# Altium schematic sheet СФ МЭИ ЛБ ПЭ2-18 11.03.04 03 Э3.SchDoc
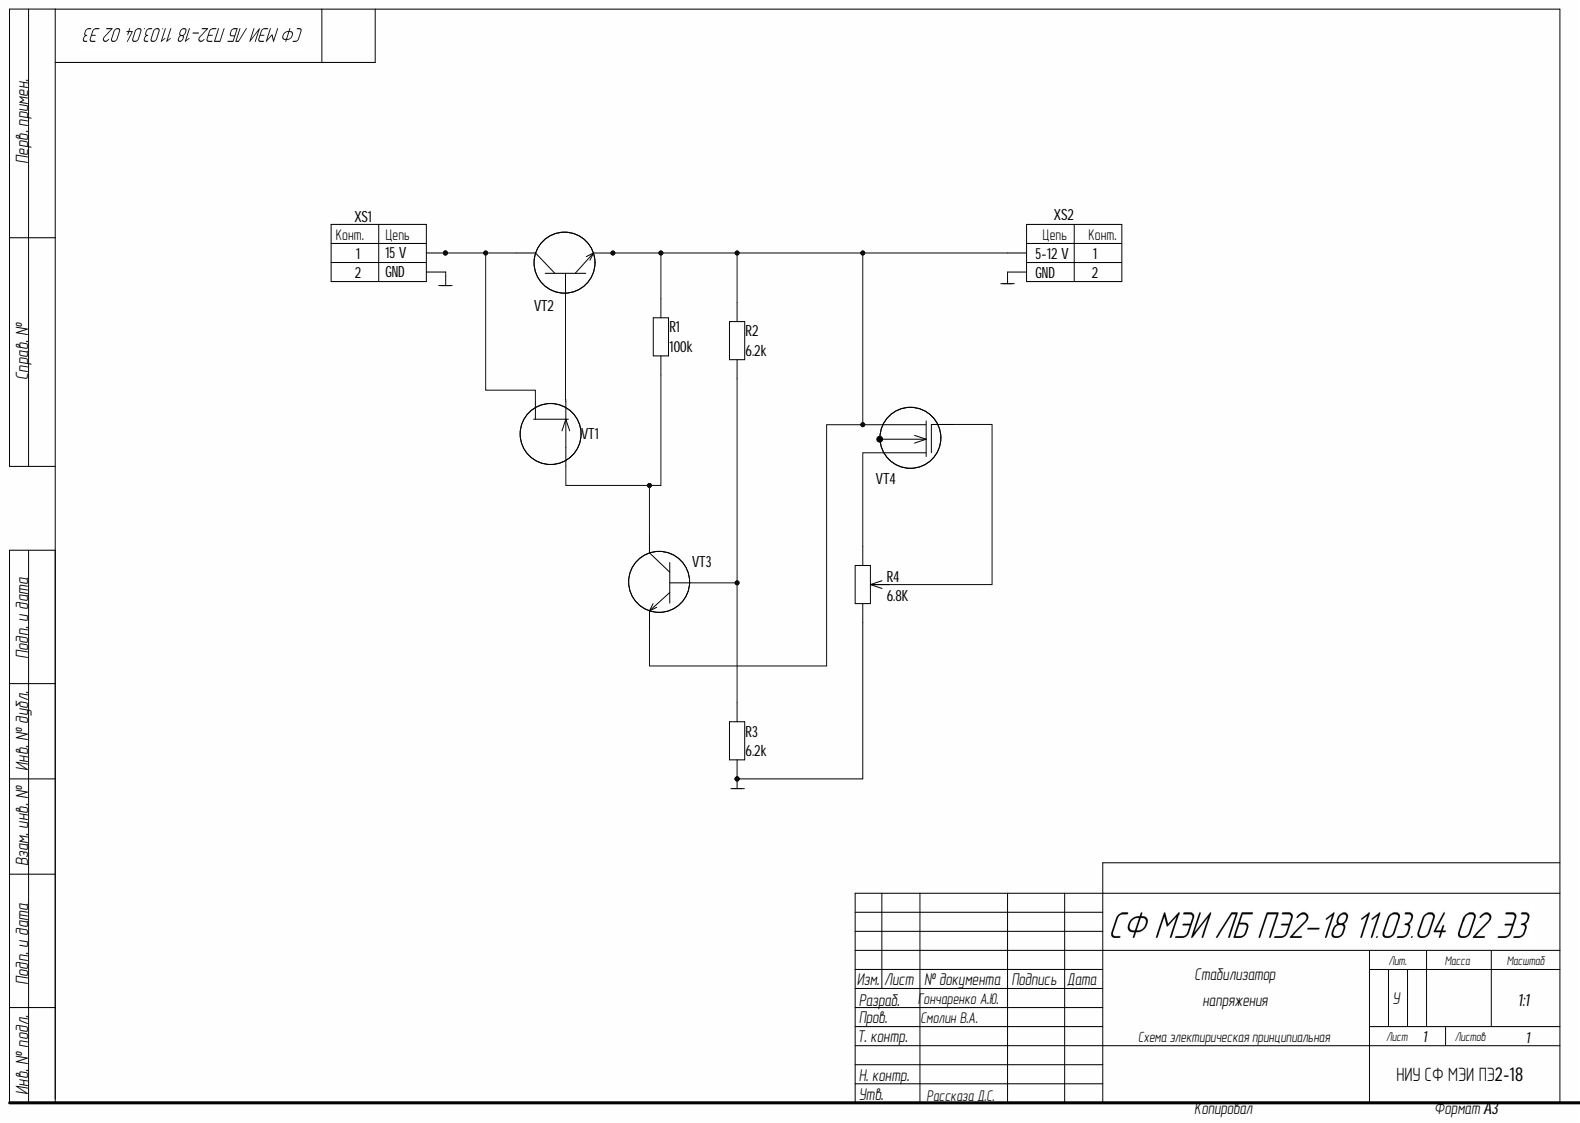
[diagram p0: СФ МЭИ ЛБ ПЭ2-18 11.03.04 03 Э3.SchDoc]

## Parts
R1  100k  [0805]
R2  6.2k  [0805]
R3  6.2k  [0805]
R4  6.8K  [ST32]
VT1  Полевойтранзистор с изолированым затвором  [SOT23]  (value not drawn)
VT2  Биполярный транзистор n-p-n  [SOT23]  (value not drawn)
VT3  Биполярный транзистор n-p-n  [SOT23]  (value not drawn)
VT4  Полевой транзистор с изолированым затвором n-типа  [SOT23]  (value not drawn)
XS1  SM02B  [CI1102M1H  (F)]  (value not drawn)
XS2  SM02B  [CI1102M1H  (F)]  (value not drawn)

## Nets
NetR1_1: R1.1 VT1.3 VT3.2
NetR1_2: R1.2 R2.2 VT2.3 VT3.3 VT4.2 XS2.1
NetR2_1: R2.1 R3.2 VT3.1
NetR3_1: R3.1 R4.2 XS1.2 XS2.2
NetR4_1: R4.1 VT4.3
NetR4_3: R4.3 VT4.1
NetVT1_1: VT1.1 VT2.1
NetVT1_2: VT1.2 VT2.2 XS1.1

## Library data of the parts
R1 = 0.125Вт 0805 100 кОм, 5%, Чип резистор (SMD): 0805-0.125 Вт -100 кОм±5%; Component Kind: Standard; Library Name: УГО.SchLib
R2 R3 = 0.125Вт 0805 6.2 кОм, 5%, Чип резистор (SMD): 0805-0.125 Вт -6.2 кОм±5%; Component Kind: Standard; Library Name: УГО.SchLib
R4 = 0.125Вт 0805 6.8 кОм, 5%, подстроечный чип резистор (SMD): 0805-0.125 Вт -6.8 кОм±5%; Component Kind: Standard; Library Name: УГО.SchLib
VT1 = IRFML8244TRPBF: Component Kind: Standard; Description: IRFML8244TRPBF; Library Name: УГО.SchLib
VT2 = BFR540: Component Kind: Standard; Description: BFR540; Library Name: УГО.SchLib
VT3 = BFR540_1: BFR540; Component Kind: Standard; Library Name: УГО.SchLib
VT4 = BSS83P H6327XTSA1: Component Kind: Standard; Description: BSS83P H6327XTSA1; Library Name: УГО.SchLib
XS1 XS2 = SM02B: Component Kind: Standard; Description: SM02B; Library Name: УГО.SchLib
